# Altium schematic sheet 407-power.schdoc(407-power)
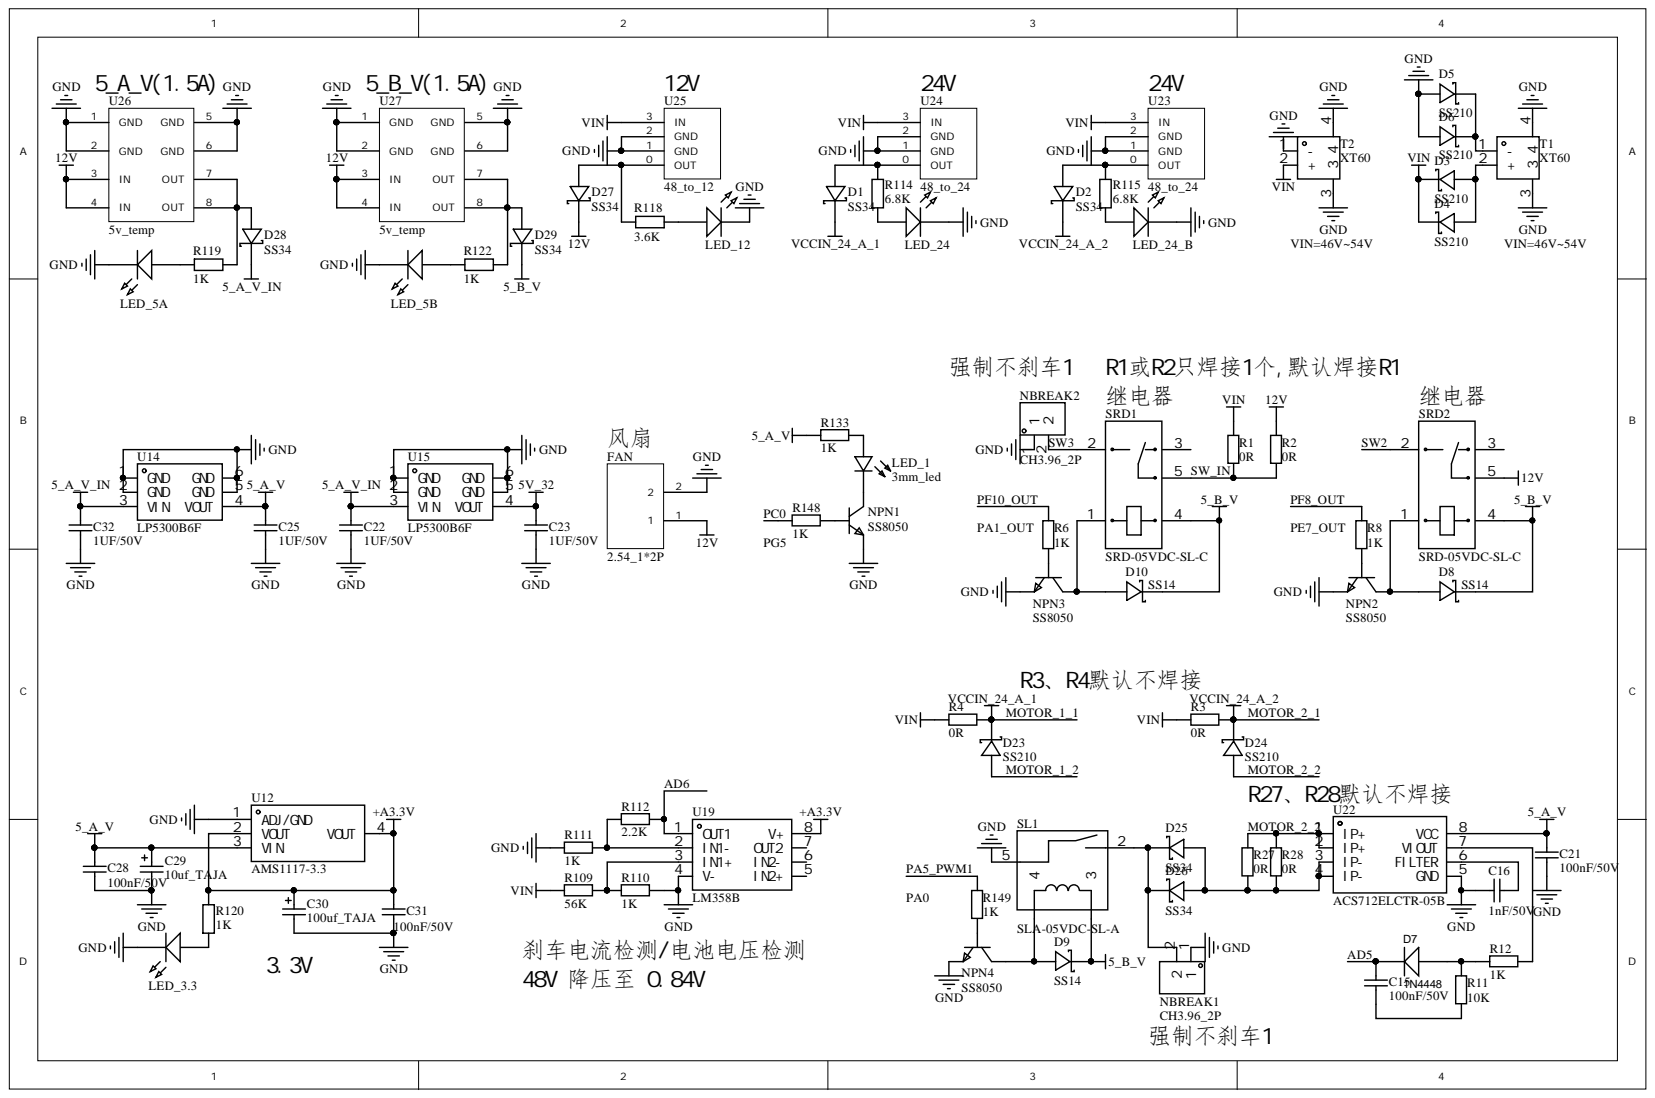
[diagram p0: 407-power.schdoc]

## Parts
C15  100nF/50V
C16  1nF/50V
C21  100nF/50V
C22  1UF/50V
C23  1UF/50V
C25  1UF/50V
C28  100nF/50V
C29  10uf_TAJA  [10uf_TAJA]
C30  100uf_TAJA  [100uf_TAJA]
C31  100nF/50V
C32  1UF/50V
D1  SS34  [SS34]
D2  SS34  [SS34]
D3  SS210  [SS210]
D4  SS210  [SS210]
D5  SS210  [SS210]
D6  SS210  [SS210]
D7  1N4448
D8  SS14  [SS14]
D9  SS14  [SS14]
D10  SS14  [SS14]
D23  SS210  [SS210]
D24  SS210  [SS210]
D25  SS34  [SS34]
D26  SS34  [SS34]
D27  SS34  [SS34]
D28  SS34  [SS34]
D29  SS34  [SS34]
FAN  2.54_1*2P  [2.54_1*2P]
LED_1  3mm_led  [3mm_led]
LED_3.3  LED  [LED]
LED_5A  LED  [LED]
LED_5B  LED  [LED]
LED_12  LED  [LED]
LED_24  LED  [LED]
LED_24_B  LED  [LED]
NBREAK1  CH3.96_2P  [CH3.96_2P]
NBREAK2  CH3.96_2P
NPN1  SS8050  [SS8050]
NPN2  SS8050  [SS8050]
NPN3  SS8050  [SS8050]
NPN4  SS8050  [SS8050]
R1  0R  [R1206_M]
R2  0R  [R1206_M]
R3  0R  [R1206_M]
R4  0R  [R1206_M]
R6  1K
R8  1K
R11  10K  value 1K
R12  1K
R27  0R  [R1206_M]
R28  0R  [R1206_M]
R109  56K  [R0603_L]
R110  1K  [R0603_L]
R111  1K  [R0603_L]
R112  2.2K  [R0603_L]
R114  6.8K
R115  6.8K
R118  3.6K
R119  1K
R120  1K
R122  1K
R133  1K
R148  1K
R149  1K
SL1  SLA-05VDC-SL-A  [SLA-05VDC-SL-A]
SRD1  SRD-05VDC-SL-C  [SRD-05VDC-SL-C]
SRD2  SRD-05VDC-SL-C  [SRD-05VDC-SL-C]
T1  XT60
T2  XT60
U12  AMS1117-3.3  [AMS1117-3.3]
U14  LP5300B6F  [LP5300B6F]
U15  LP5300B6F  [LP5300B6F]
U19  LM358B  [LM358B]
U22  ACS712ELCTR-05B  [ACS712ELCTR-05B]
U23  48_to_24  [48_to_24]
U24  48_to_24  [48_to_24]
U25  48_to_12  [48_to_12]
U26  5v_temp  [5v_temp]
U27  5v_temp  [5v_temp]

## Nets
5_A_V: C21.2 C25.2 C28.1 C29.1 R133.2 U12.3(VIN) U14.4(VOUT) U22.8(VCC)
5_A_V_IN: C22.2 C32.2 D28.1 U14.3(VIN) U15.3(VIN)
5_B_V: D8.1 D9.1 D10.1 D29.1 SL1.3 SRD1.4 SRD2.4
5V_32: C23.2 U15.4(VOUT)
12V: D27.1 FAN.1 R2.1 SRD2.5 U26.3(IN) U26.4(IN) U27.3(IN) U27.4(IN)
+A3.3V: C30.1 C31.1 R120.1 U12.2(VOUT) U12.4(VOUT) U19.8(V+)
GND: C16.2 C21.1 C22.1 C23.1 C25.1 C28.2 C29.2 C30.2 C31.2 C32.1 D5.2 D6.2 FAN.2 LED_3.3.2 LED_5A.2 LED_5B.2 LED_12.2 LED_24.2 LED_24_B.2 NBREAK1.1 NBREAK2.1 NPN1.2 NPN2.2 NPN3.2 NPN4.2 R110.1 R111.2 SL1.5 T1.3 T1.4 T2.1(-) T2.3 T2.4 U12.1(ADJ/GND) U14.1(GND) U14.2(GND) U14.5(GND) U14.6(GND) U15.1(GND) U15.2(GND) U15.5(GND) U15.6(GND) U19.4(V-) U22.5(GND) U23.1(GND) U23.2(GND) U24.1(GND) U24.2(GND) U25.1(GND) U25.2(GND) U26.1(GND) U26.2(GND) U26.5(GND) U26.6(GND) U27.1(GND) U27.2(GND) U27.5(GND) U27.6(GND)
VIN: D3.1 D4.1 R1.2 R3.2 R4.2 R109.2 T2.2(+) U23.3(IN) U24.3(IN) U25.3(IN)
AD5: C15.1 D7.1
AD6: R112.1 U19.1(OUT1)
MOTOR_1_1: D1.1 D23.1 R4.1
MOTOR_1_2: D23.2
MOTOR_2_1: D2.1 D24.1 R3.1
MOTOR_2_2: D24.2 R27.2 R28.2 U22.1(IP+) U22.2(IP+)
PA5_PWM1: R149.1
PC0: R148.1
PF8_OUT: R8.1
PF10_OUT: R6.1
SW2: SRD2.2
SW3: NBREAK2.2 SRD1.2
SW_IN: R1.1 R2.2 SRD1.5
NetC15_2: C15.2 R11.2
NetC16_1: C16.1 U22.6(FILTER)
NetD1_2: D1.2 R114.1 U24.0(OUT)
NetD2_2: D2.2 R115.1 U23.0(OUT)
NetD3_2: D3.2 D4.2 T1.2(+)
NetD5_1: D5.1 D6.1 T1.1(-)
NetD7_2: D7.2 R11.1 R12.1
NetD8_2: D8.2 NPN2.3 SRD2.1
NetD9_2: D9.2 NPN4.3 SL1.4
NetD10_2: D10.2 NPN3.3 SRD1.1
NetD25_1: D25.1 D26.1 NBREAK1.2 SL1.2
NetD25_2: D25.2 D26.2 R27.1 R28.1 U22.3(IP-) U22.4(IP-)
NetD27_2: D27.2 R118.1 U25.0(OUT)
NetD28_2: D28.2 R119.1 U26.7(OUT) U26.8(OUT)
NetD29_2: D29.2 R122.2 U27.7(OUT) U27.8(OUT)
NetLED_1_1: LED_1.1 R133.1
NetLED_1_2: LED_1.2 NPN1.3
NetLED_3.3_1: LED_3.3.1 R120.2
NetLED_5A_1: LED_5A.1 R119.2
NetLED_5B_1: LED_5B.1 R122.1
NetLED_12_1: LED_12.1 R118.2
NetLED_24_1: LED_24.1 R114.2
NetLED_24_B_1: LED_24_B.1 R115.2
NetNPN1_1: NPN1.1 R148.2
NetNPN2_1: NPN2.1 R8.2
NetNPN3_1: NPN3.1 R6.2
NetNPN4_1: NPN4.1 R149.2
NetR12_2: R12.2 U22.7(VIOUT)
NetR109_1: R109.1 R110.2 U19.3(IN1+)
NetR111_1: R111.1 R112.2 U19.2(IN1-)
PA0:
PA1_OUT:
PE7_OUT:
PG5:

Not connected: SRD1 3; SRD2 3; U19 5(IN2+) 6(IN2-) 7(OUT2)

## Library data of the parts
C15 C16 C21-C23 C25 C28 C31 C32 = Cap: Capacitor; Component Kind: Standard; LatestRevisionDate: 17-Jul-2002; LatestRevisionNote: Re-released for DXP Platform.; Library Name: Miscellaneous Devices.IntLib; PackageDescription: Radial Cap, Thru-Hole; 2 Leads; 0.3 in Pin Spacing; PackageReference: RAD-0.3; Published: 8-Jun-2000; Signal Integrity: Cap; Simulation: CAP; Publisher: Altium Limited
  C15 C21 C28 C31: Value: 100nF/50V
  C16: Value: 1nF/50V
  C22 C23 C25 C32: Value: 1UF/50V
D7 = 1N4448: Manufacturer: Diodes Inc; Manufacturer Part: 1N4448HLP-7; Avnet: 1N4448HLP-7; Component Kind: Standard; Digikey: 1N4448HLPDICT-ND; Link: https://www.diodes.com/assets/Datasheets/ds30590.pdf; spicePre: D; spiceSymbolName: 1N4448
LED_3.3 LED_5A LED_5B LED_12 LED_24 LED_24_B = LED: 发光二极管; 封装库来自淘宝卖家ID：黄晶宝石: 其他店铺购入均为盗版封装库，请立即申请退款，本店现金奖励20~200元; Component Kind: Standard; Library Name: 0.3 - LED发光二极管-IR二极管_接收管-光耦.SchLib
R1-R4 R6 R8 R11 R12 R27 R28 R133 R148 R149 = Res2: Resistor; Component Kind: Standard; LatestRevisionDate: 17-Jul-2002; LatestRevisionNote: Re-released for DXP Platform.; PackageDescription: Axial Device, Thru-Hole; 2 Leads; 0.4 in Pin Spacing; PackageReference: AXIAL-0.4; Published: 8-Jun-2000; Signal Integrity: Res; Simulation: RESISTOR; Library Name: MOS motor driving module .SCHLIB; Publisher: Altium Limited
  R1-R4 R27 R28: Value: 0R
  R6 R8 R12 R133 R148 R149: Value: 1K
R109-R112 = Res2: Resistor; Value: 0K; Component Kind: Standard; LatestRevisionDate: 17-Jul-2002; LatestRevisionNote: Re-released for DXP Platform.; PackageDescription: Axial Device, Thru-Hole; 2 Leads; 0.4 in Pin Spacing; PackageReference: AXIAL-0.4; Published: 8-Jun-2000; Signal Integrity: Res; Simulation: RESISTOR; Library Name: MOS motor driving module .SCHLIB; Publisher: Altium Limited
R114 R115 R118-R120 R122 = R: 贴片电阻; 联系卖家，免费查找封装: 唯一出售店铺网址https://shop463601444.taobao.com; BOM名称: 贴片电阻; Component Kind: Standard; Library Name: 0.1 - 电阻-电容-电感-晶振-晶体-磁珠-电位器-法拉电容-馈通电容.SchLib
C29 = 10uf_TAJA: Component Kind: Standard; Library Name: tianjin_2023.SchLib
C30 = 100uf_TAJA: Component Kind: Standard; Library Name: tianjin_2023.SchLib
D1 D2 D25-D29 = SS34: Component Kind: Standard; Library Name: tianjin_2023.SchLib
D3-D6 D23 D24 = SS210: Component Kind: Standard; Library Name: tianjin_2023.SchLib
D8-D10 = SS14: Component Kind: Standard; Library Name: tianjin_2023.SchLib
FAN = 2.54_1*2P: Component Kind: Standard; Library Name: tianjin_2023.SchLib
LED_1 = 3mm_led: Component Kind: Standard; Library Name: tianjin_2023.SchLib
NBREAK1 NBREAK2 = CH3.96_2P: Component Kind: Standard; Library Name: tianjin_2023.SchLib
NPN1-NPN4 = SS8050: Component Kind: Standard; Library Name: tianjin_2023.SchLib
SL1 = SLA-05VDC-SL-A: Component Kind: Standard; Library Name: tianjin_2023.SchLib
SRD1 SRD2 = SRD-05VDC-SL-C: Component Kind: Standard; Library Name: tianjin_2023.SchLib
T1 T2 = XT60: Component Kind: Standard; Library Name: tianjin_2023.SchLib
U12 = AMS1117-3.3: Component Kind: Standard; Library Name: tianjin_2023.SchLib
U14 U15 = LP5300B6F: Component Kind: Standard; Library Name: tianjin_2023.SchLib
U19 = LM358B: Component Kind: Standard; Library Name: tianjin_2023.SchLib
U22 = ACS712ELCTR-05B: Component Kind: Standard; Library Name: tianjin_2023.SchLib
U23 U24 = 48_to_24: Component Kind: Standard; Library Name: tianjin_2023.SchLib
U25 = 48_to_12: Component Kind: Standard; Library Name: tianjin_2023.SchLib
U26 U27 = 5v_temp: Component Kind: Standard; Library Name: tianjin_2023.SchLib
